# Altium schematic sheet MCU_Lilygo_T-CALL.SchDoc
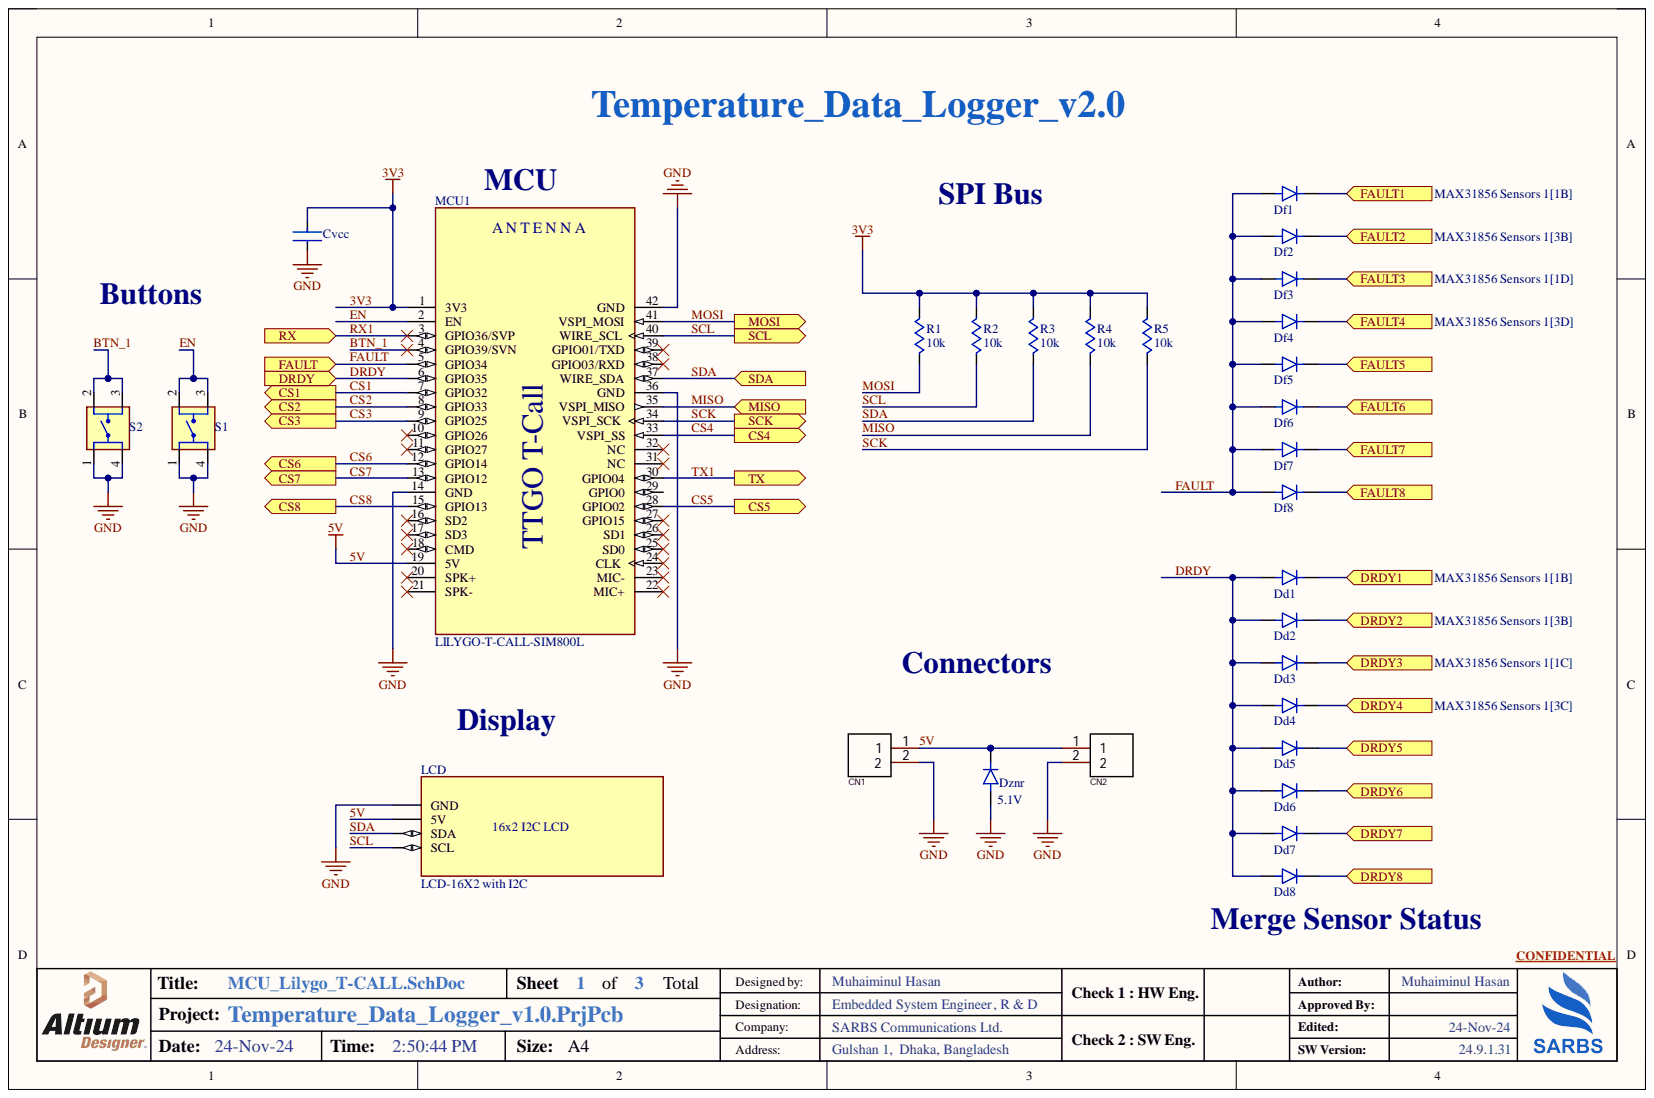
[diagram p0: MCU_Lilygo_T-CALL.SchDoc]

## Parts
CN1  WJ2EDGRC-5.08-2P  [Terminal Block P5.08-2P]  (value not drawn)
CN2  WJ2EDGRC-5.08-2P  [Terminal Block P5.08-2P]  (value not drawn)
Cvcc  (no value)  [0,1uF 25V cap TH CNC]
Dd1  (no value)  [FP-1N4007-MFG]
Dd2  (no value)  [FP-1N4007-MFG]
Dd3  (no value)  [FP-1N4007-MFG]
Dd4  (no value)  [FP-1N4007-MFG]
Dd5  (no value)  [FP-1N4007-MFG]
Dd6  (no value)  [FP-1N4007-MFG]
Dd7  (no value)  [FP-1N4007-MFG]
Dd8  (no value)  [FP-1N4007-MFG]
Df1  (no value)  [FP-1N4007-MFG]
Df2  (no value)  [FP-1N4007-MFG]
Df3  (no value)  [FP-1N4007-MFG]
Df4  (no value)  [FP-1N4007-MFG]
Df5  (no value)  [FP-1N4007-MFG]
Df6  (no value)  [FP-1N4007-MFG]
Df7  (no value)  [FP-1N4007-MFG]
Df8  (no value)  [FP-1N4007-MFG]
Dznr  5.1V  [FP-1N4007-MFG]
LCD  LCD-16X2 with I2C  MP: LCD-16X2  [MODULE_LCD-16X2 I2C]
MCU1  LILYGO-T-CALL-SIM800L  [XCVR_LILYGO-T-CALL-SIM800L CNC]
R1  10k  [RESA60-630X240]
R2  10k  [RESA60-630X240]
R3  10k  [RESA60-630X240]
R4  10k  [RESA60-630X240]
R5  10k  [RESA60-630X240]
S1  FSM8JH  [SW_FSM8JH]  (value not drawn)
S2  FSM8JH  [SW_FSM8JH]  (value not drawn)

## Ports
CS1, CS2, CS3, CS4, CS5, CS6, CS7, CS8, DRDY, DRDY1, DRDY2, DRDY3, DRDY4, DRDY5, DRDY6, DRDY7, DRDY8, FAULT, FAULT1, FAULT2, FAULT3, FAULT4, FAULT5, FAULT6, FAULT7, FAULT8, MISO, MOSI, RX, SCK, SCL, SDA, TX

## Nets
GND: CN1.2 CN2.2 Cvcc.2 Dznr.2 LCD.4(e) MCU1.14(GND) MCU1.36(GND) MCU1.42(GND) S1.1 S1.4 S2.1 S2.4
3V3: Cvcc.1 MCU1.1(3V3) R1.2 R2.2 R3.2 R4.2 R5.2
5V: CN1.1 CN2.1 Dznr.1 LCD.3(n) MCU1.19(5V)
BTN_1: MCU1.4(GPIO39/SVN) S2.2 S2.3
CS1: MCU1.7(GPIO32) ; port CS1
CS2: MCU1.8(GPIO33) ; port CS2
CS3: MCU1.9(GPIO25) ; port CS3
CS4: MCU1.33(VSPI_SS) ; port CS4
CS5: MCU1.28(GPIO02) ; port CS5
CS6: MCU1.12(GPIO14) ; port CS6
CS7: MCU1.13(GPIO12) ; port CS7
CS8: MCU1.15(GPIO13) ; port CS8
DRDY: Dd1.2 Dd2.2 Dd3.2 Dd4.2 Dd5.2 Dd6.2 Dd7.2 Dd8.2 MCU1.6(GPIO35) ; port DRDY
DRDY1: Dd1.1 ; port DRDY1
DRDY2: Dd2.1 ; port DRDY2
DRDY3: Dd3.1 ; port DRDY3
DRDY4: Dd4.1 ; port DRDY4
DRDY5: Dd5.1 ; port DRDY5
DRDY6: Dd6.1 ; port DRDY6
DRDY7: Dd7.1 ; port DRDY7
DRDY8: Dd8.1 ; port DRDY8
EN: MCU1.2(EN) S1.2 S1.3
FAULT: Df1.2 Df2.2 Df3.2 Df4.2 Df5.2 Df6.2 Df7.2 Df8.2 MCU1.5(GPIO34) ; port FAULT
FAULT1: Df1.1 ; port FAULT1
FAULT2: Df2.1 ; port FAULT2
FAULT3: Df3.1 ; port FAULT3
FAULT4: Df4.1 ; port FAULT4
FAULT5: Df5.1 ; port FAULT5
FAULT6: Df6.1 ; port FAULT6
FAULT7: Df7.1 ; port FAULT7
FAULT8: Df8.1 ; port FAULT8
MISO: MCU1.35(VSPI_MISO) R4.1 ; port MISO
MOSI: MCU1.41(VSPI_MOSI) R1.1 ; port MOSI
RX1: MCU1.3(GPIO36/SVP) ; port RX
SCK: MCU1.34(VSPI_SCK) R5.1 ; port SCK
SCL: LCD.1(SCL) MCU1.40(WIRE_SCL) R2.1 ; port SCL
SDA: LCD.2(SDA) MCU1.37(WIRE_SDA) R3.1 ; port SDA
TX1: MCU1.30(GPIO04) ; port TX

Not connected: MCU1 10(GPIO26) 11(GPIO27) 16(SD2) 17(SD3) 18(CMD) 20(SPK+) 21(SPK-) 22(MIC+) 23(MIC-) 24(CLK) 25(SD0) 26(SD1) 27(GPIO15) 29(GPIO0) 31(NC) 32(NC) 38(GPIO03/RXD) 39(GPIO01/TXD)

## Library data of the parts
CN1 CN2 = WJ2EDGRC-5.08-2P: Manufacturer: KANGNEX(康奈克斯电气); Add into BOM: yes; Connector Type: 板端/Receptacle-Close; Number of PINs Per Row: 2; Pitch: 5.08mm; Structure: 1x2P; Color: Green; Component Kind: Standard; Convert to PCB: yes; Datasheet: https://atta.szlcsc.com/upload/public/pdf/source/20191225/C3697_6E7B45CD3FD3DA2CDF6A2CE707235E10.pdf; Device: WJ2EDGRC-5.08-2P; JLCPCB Part Class: Extended Part; LCSC Part Name: 5.08mm 1x2P 排数:1 每排P数:2; Library Name: Terminal Block P5.08-2P.SchLib; Manufacturer Part: WJ2EDGRC-5.08-2P; Mounting Style: Bend; Number of Pins: 2P; Number of Rows: 1; Supplier: LCSC; Supplier Footprint: 弯插,P=5.08mm; Supplier Part: C3697; Symbol: WJ2EDGRC-5.08-2P
Cvcc = CMP-06035-046728-1: Ceramic Capacitor 100nF ±10% 25V X7R Radial; Lead Diameter: 0.5mm; Capacitance: 100nF; Case/Package: Radial; Component Kind: Standard; Dielectric: X7R; Height: 3.5mm; Height - Seated (Max): 0.236inch; Lead Free: Lead Free; Lead Pitch: 0.098inch; Length: 0.197inch; Library Name: 0.1uF 25V cap cer TH.SchLib; Max Operating Temperature: 125°C; Min Operating Temperature: -55°C; Mount: Through Hole; Package Quantity: 2000; Packaging: Tape and Reel; Resistor Type: Ceramic; Termination: Radial; Tolerance: 10%; Voltage Rating: 25V; Voltage Rating (DC): 25V; Width: 3.5mm
Dd1-Dd8 Df1-Df8 Dznr = CMP-02540-000046-1: DIODE GEN PURP 1KV 1A DO204AL; Manufacturer: Vishay; Manufacturer Part Number: 1N4007-E3/54; Capacitance: 15pF; Max Junction Temp: +150°C; Max Repetitive Reverse Voltage: 1000V; Max Surge Forward Current: 30A; Peak Reverse Current: 30uA; Value: 15pF; Automotive: No; Category: Diode; Component Kind: Standard; ComponentLink2Description: Datasheet; ComponentLink2URL: http://www.vishay.com/docs/88503/1n4001.pdf; Device Class L1: Discrete Semiconductors; Device Class L2: Diodes; Device Class L3: Rectifier Diodes; Digikey Description: DIODE GEN PURP 1KV 1A DO204AL; Forward Current: 1A; Height: 2.7mm; Ipc Land Pattern Name: DIOPA1088W105L520D270; Lead Free: Yes; Library Name: 1N4007.SchLib; Mouser Description: Rectifiers Vr/1000V Io/1A; Octopart: https://octopart.com/1n4007-e3%2F54-vishay-39548207; Package: 1N4007; RoHS: TRUE; Temperature: -50°C to +150°C; Temperature Range High: +150°C; Temperature Range Low: -50°C
LCD = LCD-16X2 with I2C: Display Development Tools 16x2 Black on Green Char LCD w Backlight; MF: Gravitech; Availability: Not in stock; Check_prices: https://www.snapeda.com/parts/LCD-16X2/Gravitech/view-part/?ref=eda; Component Kind: Standard; Library Name: LCD Module 16x2.SchLib; Package: None; Price: None; SnapEDA_Link: https://www.snapeda.com/parts/LCD-16X2/Gravitech/view-part/?ref=snap
MCU1 = LILYGO-T-CALL-SIM800L: ESP32 Wireless Module GPRS Antenna SIM Card SIM800L Module CNC; MF: LILYGO; Availability: Not in stock; Check_prices: https://www.snapeda.com/parts/LilyGo-T-Call-SIM800L/LILYGO/view-part/?ref=eda; Component Kind: Standard; Library Name: LILYGO-T-CALL-SIM800L.SchLib; MP: LilyGo-T-Call-SIM800L; Package: Package; Price: None; SnapEDA_Link: https://www.snapeda.com/parts/LilyGo-T-Call-SIM800L/LILYGO/view-part/?ref=snap
R1-R5 = CMP-1659-00075-1: Axial Resistor,; Power Rating: 250 mW; Resistance: 1 kohm; Tolerance: 1%; Voltage Rating: 250 V; Case\Package: Axial; Component Kind: Standard; ComponentLink1Description: Manufacturer URL; ComponentLink1URL: http://www.yageo.com/; ComponentLink2Description: Datasheet; ComponentLink2URL: http://www.yageo.com/documents/recent/Yageo%20LR_MFR_2013.pdf; DatasheetVersion: Apr-13; Length: 0.248 inch; Library Name: Resistor Generic.SchLib; Max Operating Temperature: 155 degC; Min Operating Temperature: -55 degC; Package Quantity: 200; PackageDescription: 2-Pin Axial Lead, Dia 2.4 mm, Body Length 6.3 mm; PackageReference: MFR-25; Packaging: Bulk; RoHSCompliant: true
S1 S2 = FSM8JH: Tactile Switch SPST-NO Top Actuated Through Hole; MF: TE Connectivity; Availability: In Stock; Check_prices: https://www.snapeda.com/parts/FSM8JH/TE+Connectivity+ALCOSWITCH+Switches/view-part/?ref=eda; Component Kind: Standard; Library Name: Push button.SchLib; MP: FSM8JH; Package: None; Price: None; SnapEDA_Link: https://www.snapeda.com/parts/FSM8JH/TE+Connectivity+ALCOSWITCH+Switches/view-part/?ref=snap
